# Altium schematic sheet LCD_Mipi.SchDoc
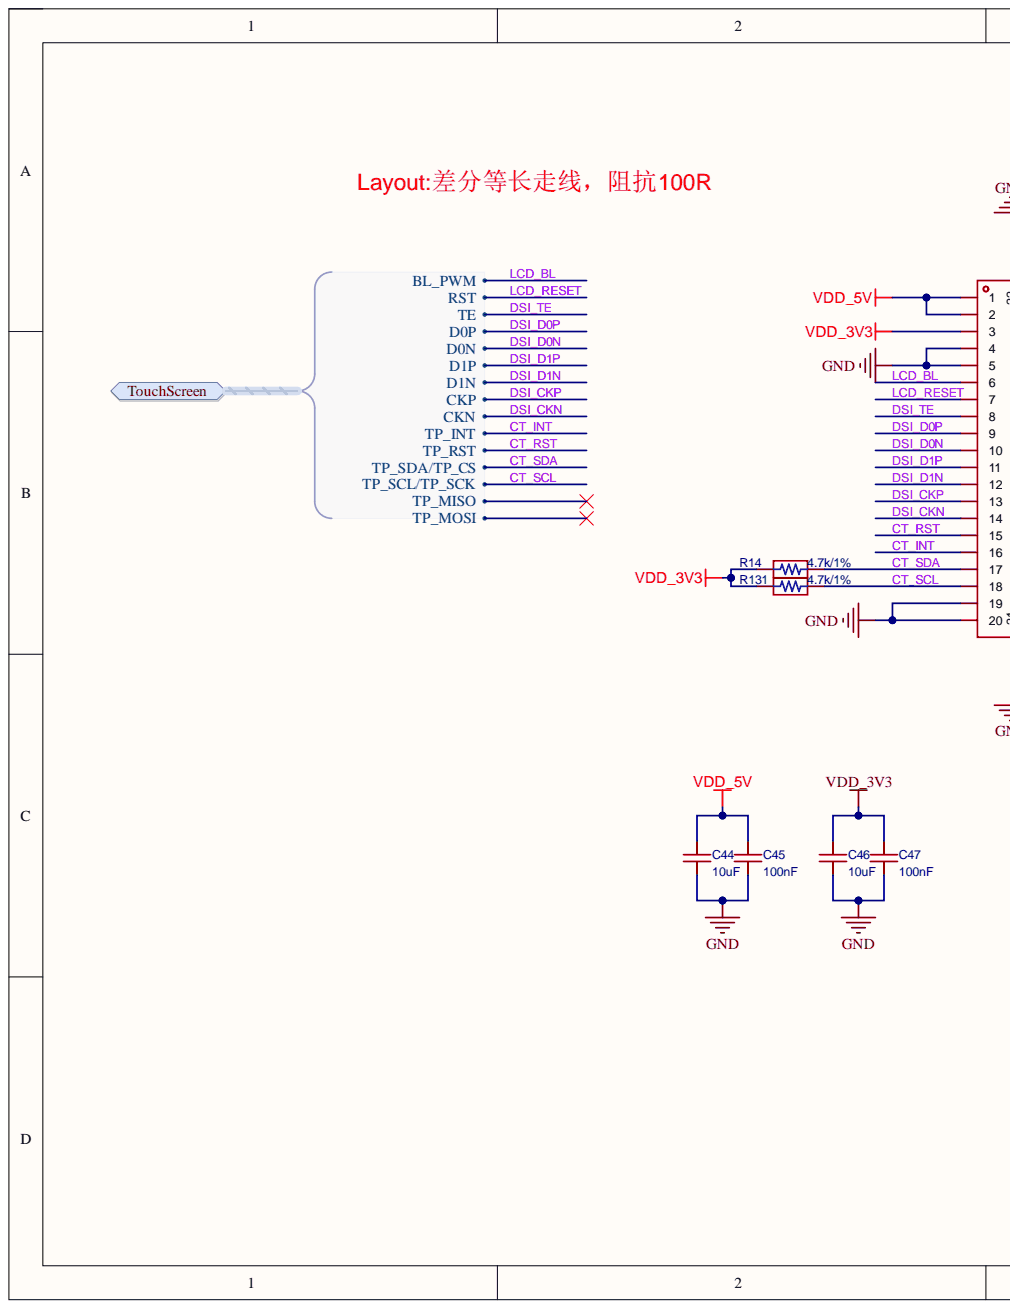
[diagram p0: LCD_Mipi.SchDoc, left]
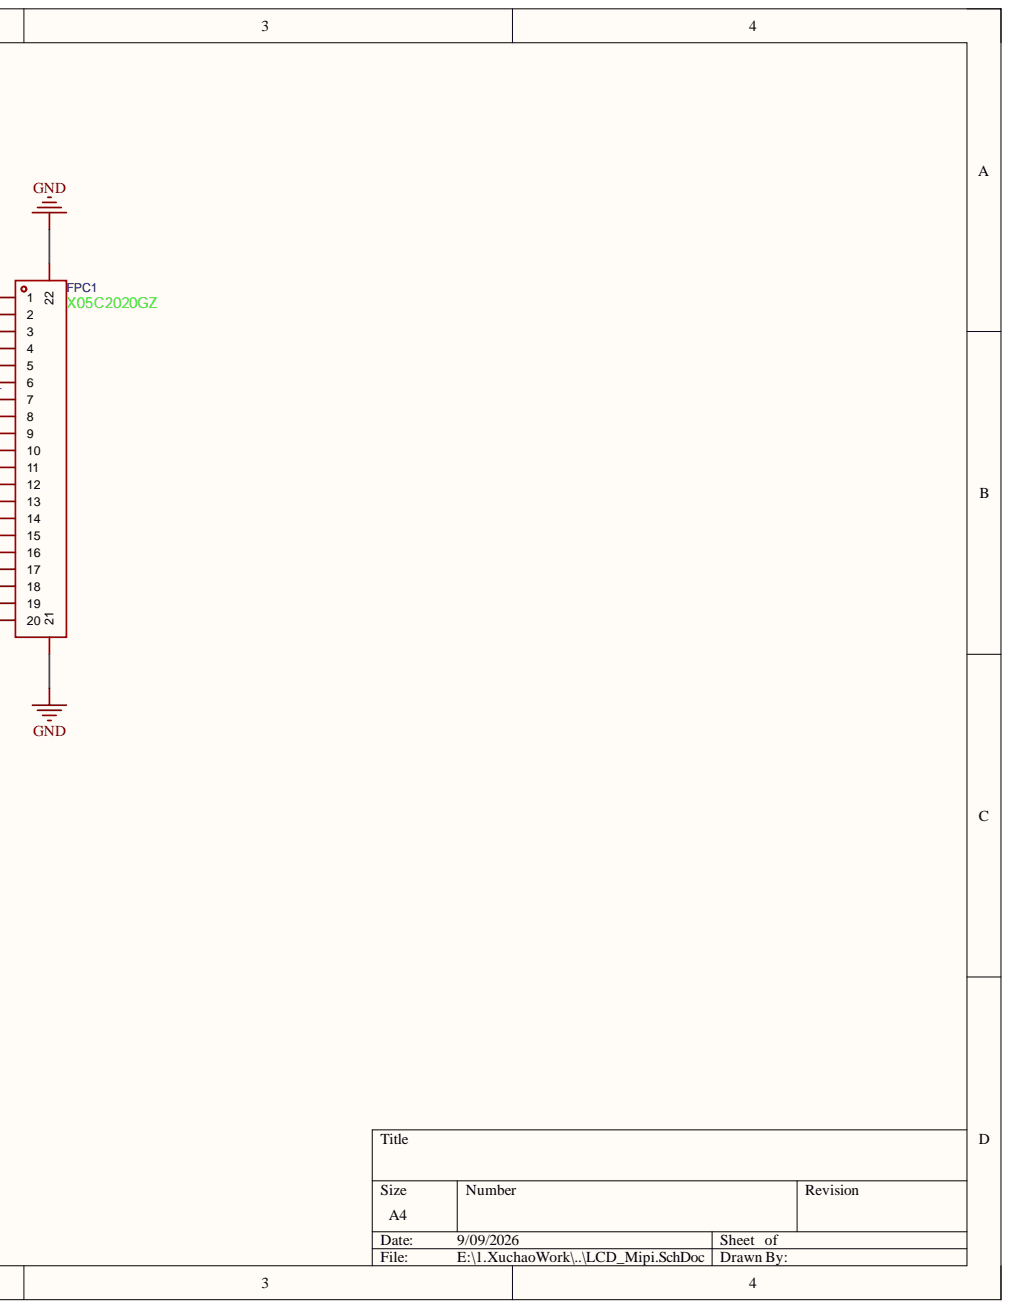
[diagram p1: LCD_Mipi.SchDoc, right]

## Parts
C44  10uF  [C0402]
C45  100nF  [C0402]
C46  10uF  [C0402]
C47  100nF  [C0402]
FPC1  X05C2020GZ  [FPC-SMD_20P-P0.50_HDGC_0.5K-A-20PB]
R14  4.7k/1%  [R0402]
R131  4.7k/1%  [R0402]

## Ports
TouchScreen, TouchScreen.BL_PWM, TouchScreen.CKN, TouchScreen.CKP, TouchScreen.D0N, TouchScreen.D0P, TouchScreen.D1N, TouchScreen.D1P, TouchScreen.RST, TouchScreen.TE, TouchScreen.TP_INT, TouchScreen.TP_MISO, TouchScreen.TP_MOSI, TouchScreen.TP_RST, TouchScreen.TP_SCL/TP_SCK, TouchScreen.TP_SDA/TP_CS

## Nets
GND: C44.1 C45.1 C46.1 C47.1 FPC1.4 FPC1.5 FPC1.19 FPC1.20 FPC1.21 FPC1.22
VDD_3V3: C46.2 C47.2 FPC1.3 R14.2 R131.2
VDD_5V: C44.2 C45.2 FPC1.1 FPC1.2
CT_INT: FPC1.16 ; port TouchScreen
CT_RST: FPC1.15 ; port TouchScreen
CT_SCL: FPC1.18 R131.1 ; port TouchScreen
CT_SDA: FPC1.17 R14.1 ; port TouchScreen
DSI_CKN: FPC1.14 ; port TouchScreen
DSI_CKP: FPC1.13 ; port TouchScreen
DSI_D0N: FPC1.10 ; port TouchScreen
DSI_D0P: FPC1.9 ; port TouchScreen
DSI_D1N: FPC1.12 ; port TouchScreen
DSI_D1P: FPC1.11 ; port TouchScreen
DSI_TE: FPC1.8 ; port TouchScreen
LCD_BL: FPC1.6 ; port TouchScreen
LCD_RESET: FPC1.7 ; port TouchScreen
N00001: ; port TouchScreen
N00002: ; port TouchScreen

## Library data of the parts
C44-C47 = 0SMD_CAP: Symbol: CL10A106KP8NNNC; Component Kind: Standard; Library Name: CYKJ_LibPkg.IntLib
FPC1 = FPC-05F-X05C2020GZ: Component Kind: Standard; Symbol: X05C2020GZ; Library Name: CYKJ_library.SchLib
R14 R131 = Resisteors: RES_SMD; Component Kind: Standard; Library Name: CYKJ_library.SchLib
